# Altium schematic sheet 兜售机器人V01.SchDoc
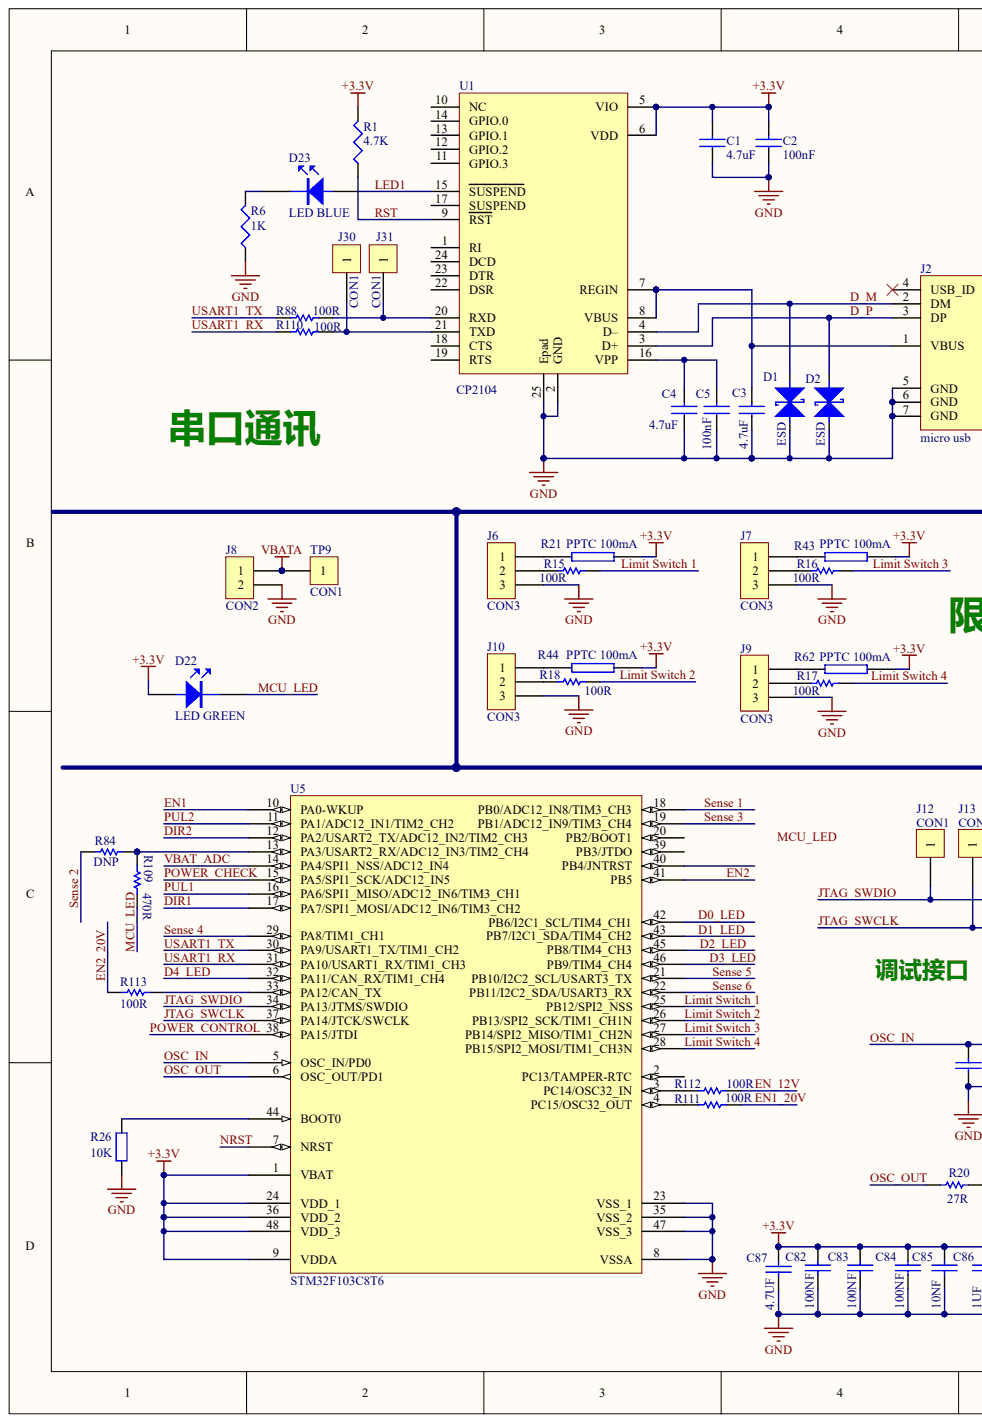
[diagram p0: 兜售机器人V01.SchDoc, left]
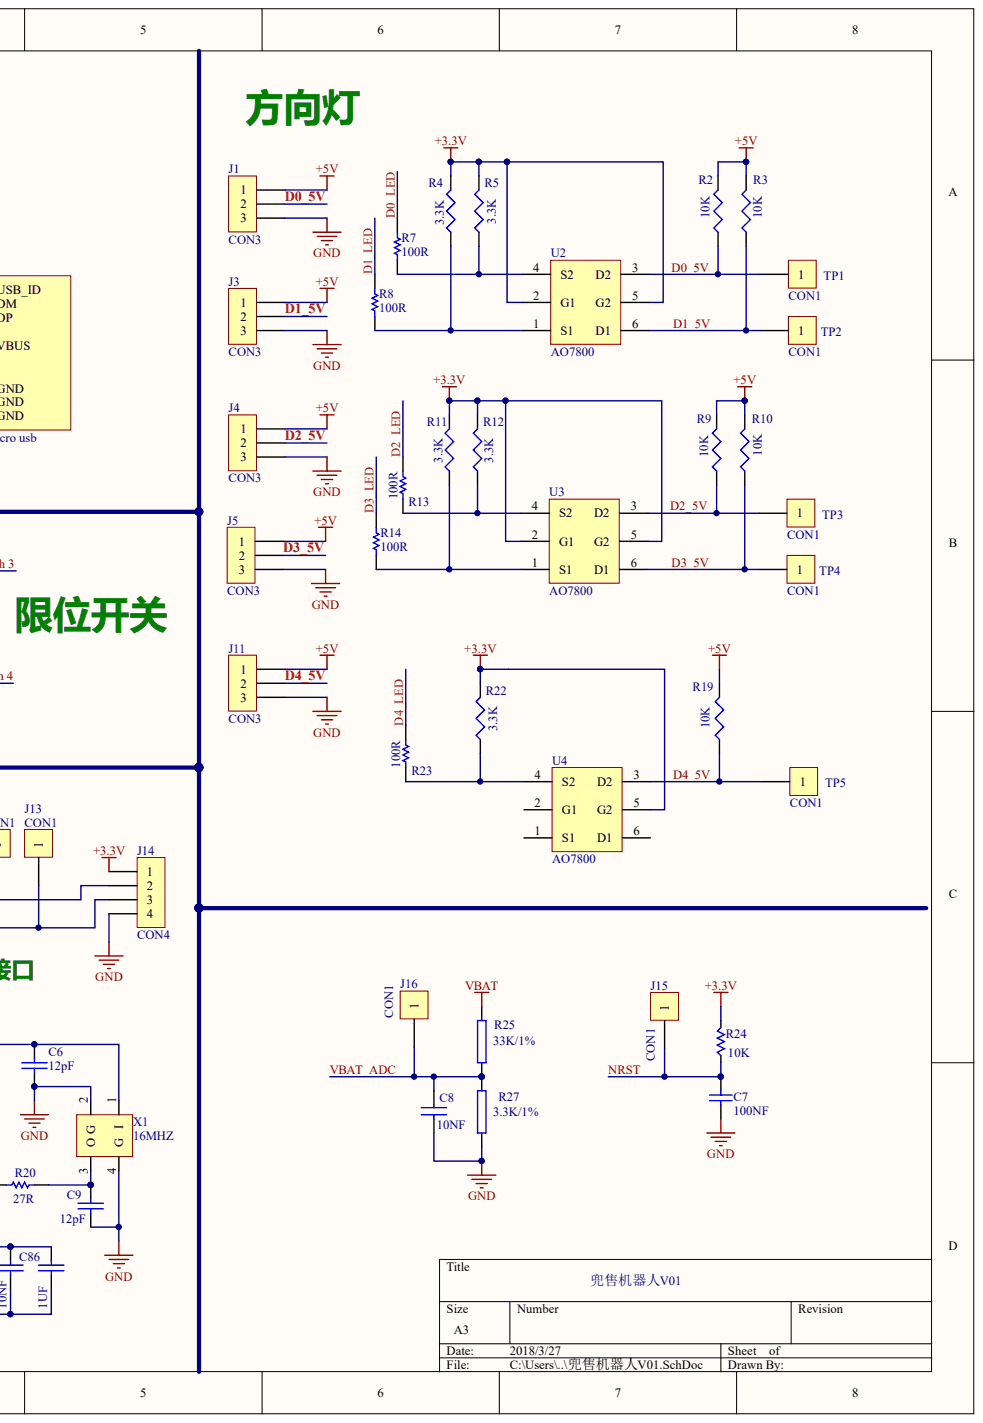
[diagram p1: 兜售机器人V01.SchDoc, right]

## Parts
C1  4.7uF
C2  100nF
C3  4.7uF
C4  4.7uF
C5  100nF
C6  12pF
C7  100NF
C8  10NF
C9  12pF
C82  100NF
C83  100NF
C84  100NF
C85  10NF
C86  1UF
C87  4.7UF
D1  ESD
D2  ESD
D22  LED GREEN
D23  LED BLUE
J1  CON3
J2  micro usb
J3  CON3
J4  CON3
J5  CON3
J6  CON3
J7  CON3
J8  CON2
J9  CON3
J10  CON3
J11  CON3
J12  CON1
J13  CON1
J14  CON4
J15  CON1
J16  CON1
J30  CON1
J31  CON1
R1  4.7K
R2  10K
R3  10K
R4  3.3K
R5  3.3K
R6  1K
R7  100R
R8  100R
R9  10K
R10  10K
R11  3.3K
R12  3.3K
R13  100R
R14  100R
R15  100R
R16  100R
R17  100R
R18  100R
R19  10K
R20  27R
R21  PPTC 100mA
R22  3.3K
R23  100R
R24  10K
R25  33K/1%
R26  10K
R27  3.3K/1%
R43  PPTC 100mA
R44  PPTC 100mA
R62  PPTC 100mA
R84  DNP
R88  100R
R109  470R
R110  100R
R111  100R
R112  100R
R113  100R
TP1  CON1
TP2  CON1
TP3  CON1
TP4  CON1
TP5  CON1
TP9  CON1
U1  CP2104
U2  AO7800
U3  AO7800
U4  AO7800
U5  STM32F103C8T6
X1  16MHZ

## Nets
+3.3V: C1.2 C2.2 C82.1 C83.1 C84.1 C85.1 C86.1 C87.1 D22.1 J14.1 R1.1 R4.2 R5.2 R11.2 R12.2 R21.2 R22.2 R24.2 R43.2 R44.2 R62.2 U1.5(VIO) U1.6(VDD) U2.2(G1) U2.5(G2) U3.2(G1) U3.5(G2) U4.5(G2) U5.1(VBAT) U5.9(VDDA) U5.24(VDD_1) U5.36(VDD_2) U5.48(VDD_3)
+5V: J1.1 J3.1 J4.1 J5.1 J11.1 R2.2 R3.2 R9.2 R10.2 R19.2
GND: C1.1 C2.1 C3.1 C4.1 C5.1 C6.2 C7.1 C8.2 C9.1 C82.2 C83.2 C84.2 C85.2 C86.2 C87.2 D1.1 D2.1 J1.3 J2.5(GND) J2.6(GND) J2.7(GND) J3.3 J4.3 J5.3 J6.3 J7.3 J8.2 J9.3 J10.3 J11.3 J14.4 R6.2 R26.1 R27.1 U1.2(GND) U1.25(Epad) U5.8(VSSA) U5.23(VSS_1) U5.35(VSS_2) U5.47(VSS_3) X1.2(G) X1.4(G)
VBAT: R25.2
VBATA: J8.1 TP9.1
D0_5V: J1.2 R2.1 TP1.1 U2.3(D2)
D0_LED: R7.2 U5.42(PB6/I2C1_SCL/TIM4_CH1)
D1_5V: J3.2 R3.1 TP2.1 U2.6(D1)
D1_LED: R8.1 U5.43(PB7/I2C1_SDA/TIM4_CH2)
D2_5V: J4.2 R9.1 TP3.1 U3.3(D2)
D2_LED: R13.1 U5.45(PB8/TIM4_CH3)
D3_5V: J5.2 R10.1 TP4.1 U3.6(D1)
D3_LED: R14.1 U5.46(PB9/TIM4_CH4)
D4_5V: J11.2 R19.1 TP5.1 U4.3(D2)
D4_LED: R23.1 U5.32(PA11/CAN_RX/TIM1_CH4)
D_M: D1.2 J2.2(DM) U1.4(D–)
D_P: D2.2 J2.3(DP) U1.3(D+)
DIR1: U5.17(PA7/SPI1_MOSI/ADC12_IN6/TIM3_CH2)
DIR2: U5.12(PA2/USART2_TX/ADC12_IN2/TIM2_CH3)
EN1: U5.10(PA0-WKUP)
EN1_20V: R111.1
EN2: U5.41(PB5)
EN2_20V: R113.1
EN_12V: R112.1
JTAG_SWCLK: J13.1 J14.3 U5.37(PA14/JTCK/SWCLK)
JTAG_SWDIO: J12.1 J14.2 U5.34(PA13/JTMS/SWDIO)
LED1: D23.1 U1.15(SUSPEND)
LIMIT SWITCH 1: R15.1 U5.25(PB12/SPI2_NSS)
LIMIT SWITCH 2: R18.1 U5.26(PB13/SPI2_SCK/TIM1_CH1N)
LIMIT SWITCH 3: R16.1 U5.27(PB14/SPI2_MISO/TIM1_CH2N)
LIMIT SWITCH 4: R17.1 U5.28(PB15/SPI2_MOSI/TIM1_CH3N)
MCU_LED: D22.2 R109.2
NRST: C7.2 J15.1 R24.1 U5.7(NRST)
OSC_IN: C6.1 U5.5(OSC_IN/PD0) X1.1(I)
OSC_OUT: R20.2 U5.6(OSC_OUT/PD1)
POWER_CHECK: U5.15(PA5/SPI1_SCK/ADC12_IN5)
POWER_CONTROL: U5.38(PA15/JTDI)
PUL1: U5.16(PA6/SPI1_MISO/ADC12_IN6/TIM3_CH1)
PUL2: U5.11(PA1/ADC12_IN1/TIM2_CH2)
RST: R1.2 U1.9(RST)
SENSE 1: U5.18(PB0/ADC12_IN8/TIM3_CH3)
SENSE 2: R84.2
SENSE 3: U5.19(PB1/ADC12_IN9/TIM3_CH4)
SENSE 4: U5.29(PA8/TIM1_CH1)
SENSE 5: U5.21(PB10/I2C2_SCL/USART3_TX)
SENSE 6: U5.22(PB11/I2C2_SDA/USART3_RX)
USART1_RX: R110.2 U5.31(PA10/USART1_RX/TIM1_CH3)
USART1_TX: R88.2 U5.30(PA9/USART1_TX/TIM1_CH2)
VBAT_ADC: C8.1 J16.1 R25.1 R27.2 U5.14(PA4/SPI1_NSS/ADC12_IN4)
NetC3_2: C3.2 J2.1(VBUS) U1.7(REGIN) U1.8(VBUS)
NetC4_2: C4.2 C5.2 U1.16(VPP)
NetC9_2: C9.2 R20.1 X1.3(O)
NetD23_2: D23.2 R6.1
NetJ6_1: J6.1 R21.1
NetJ6_2: J6.2 R15.2
NetJ7_1: J7.1 R43.1
NetJ7_2: J7.2 R16.2
NetJ9_1: J9.1 R62.1
NetJ9_2: J9.2 R17.2
NetJ10_1: J10.1 R44.1
NetJ10_2: J10.2 R18.2
NetJ30_1: J30.1 R110.1 U1.21(TXD)
NetJ31_1: J31.1 R88.1 U1.20(RXD)
NetR4_1: R4.1 R8.2 U2.1(S1)
NetR5_1: R5.1 R7.1 U2.4(S2)
NetR11_1: R11.1 R14.2 U3.1(S1)
NetR12_1: R12.1 R13.2 U3.4(S2)
NetR22_1: R22.1 R23.2 U4.4(S2)
NetR26_2: R26.2 U5.44(BOOT0)
NetR84_1: R84.1 R109.1 U5.13(PA3/USART2_RX/ADC12_IN3/TIM2_CH4)
NetR111_2: R111.2 U5.4(PC15/OSC32_OUT)
NetR112_2: R112.2 U5.3(PC14/OSC32_IN)
NetR113_2: R113.2 U5.33(PA12/CAN_TX)
N00031:
兜售机器人V01:

Not connected: J2 4(USB_ID); U1 1(RI) 10(NC) 11(GPIO.3) 12(GPIO.2) 13(GPIO.1) 14(GPIO.0) 17(SUSPEND) 18(CTS) 19(RTS) 22(DSR) 23(DTR) 24(DCD); U4 1(S1) 2(G1) 6(D1); U5 2(PC13/TAMPER-RTC) 20(PB2/BOOT1) 39(PB3/JTDO) 40(PB4/JNTRST)

## Library data of the parts
R7 R8 R13-R18 R20 R23 R84 R88 R109-R113 = (no symbol name): 额定值: 10K; 精度: 5%; Value: 47K; Published: 8-Jun-2000; LatestRevisionDate: 17-Jul-2002; LatestRevisionNote: Re-released for DXP Platform.; PackageReference: AXIAL-0.3; PackageDescription: Axial Device, Thru-Hole; 2 Leads; 0.3 in Pin Spacing; 耐压: *; 材质: *; Publisher: Altium Limited
C1-C9 C82-C87 D1 D2 D22 D23 J1-J16 J30 J31 R1-R6 R9-R12 R19 R21 R22 R24-R27 R43 R44 R62 TP1-TP5 TP9 U1-U5 X1 = (no symbol name)
  C7: Published: 8-Jun-2000; LatestRevisionDate: 17-Jul-2002; LatestRevisionNote: Re-released for DXP Platform.; PackageReference: RAD-0.3; PackageDescription: Radial Cap, Thru-Hole; 2 Leads; 0.3 in Pin Spacing; Value: 100NF; Publisher: Altium Limited
